# Altium schematic sheet 01_Power.SchDoc(01_Power)
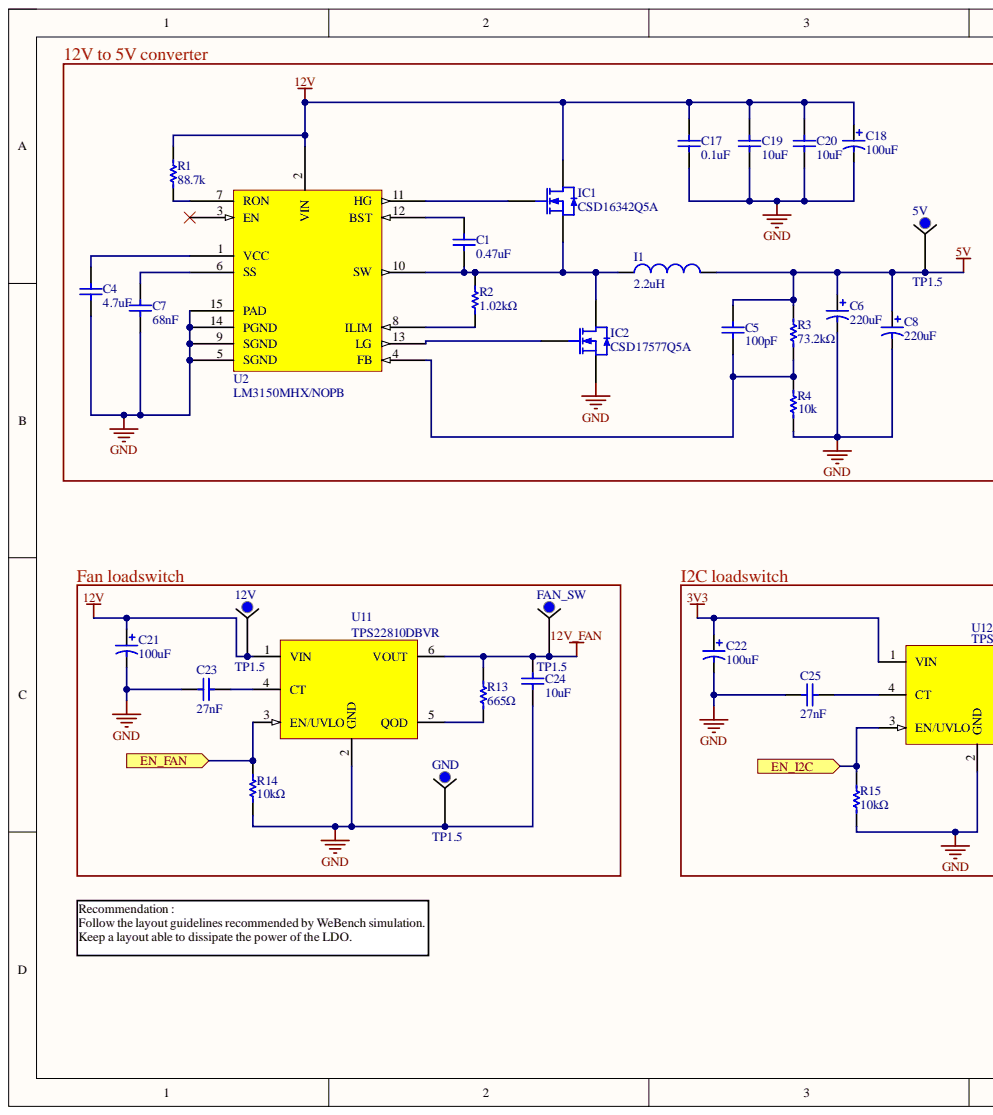
[diagram p0: 01_Power.SchDoc, left]
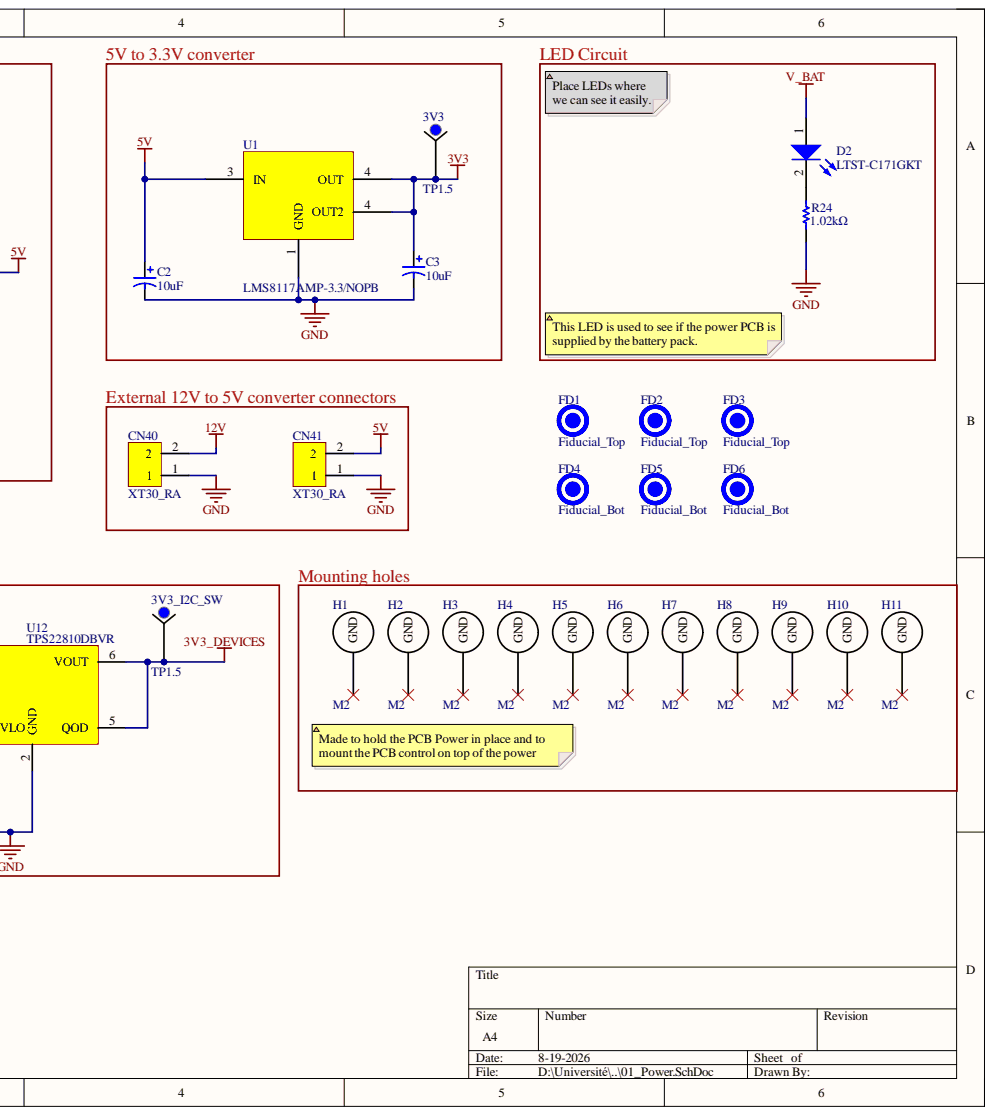
[diagram p1: 01_Power.SchDoc, right]

## Parts
3V3  TP1.5  [TP1.5]  (no BOM)
3V3_I2C_SW  TP1.5  [TP1.5]  (no BOM)
5V  TP1.5  [TP1.5]  (no BOM)
12V  TP1.5  [TP1.5]  (no BOM)
C1  0.47uF  [CAPC200X125X145L50N]  (no BOM)
C2  10uF  [CAPPM320X160X180L80X120N]  (no BOM)
C3  10uF  [CAPPM320X160X180L80X120N]  (no BOM)
C4  4.7uF  [CAPC200X125X145L50N]  (no BOM)
C5  100pF  [CAPC200X125X95L50N]  (no BOM)
C6  220uF  [CAPAE530X600N]  (no BOM)
C7  68nF  [CAPC200X125X95L50N]  (no BOM)
C8  220uF  [CAPAE530X600N]  (no BOM)
C17  0.1uF  [CAPC200X125X95L50N]  (no BOM)
C18  100uF  [CAPAE660X615D730L260X70N]  (no BOM)
C19  10uF  [CAPC320X250X270L60N]  (no BOM)
C20  10uF  [CAPC320X250X270L60N]  (no BOM)
C21  100uF  [CAPAE660X615D730L260X70N]
C22  100uF  [CAPAE660X615D730L260X70N]
C23  27nF  [CAPC200X125X145L50N]  (no BOM)
C24  10uF  [CAPC200X125X145L50N]
C25  27nF  [CAPC200X125X145L50N]  (no BOM)
CN40  XT30_RA  [XT30-90DEG]  (no BOM)
CN41  XT30_RA  [XT30-90DEG]  (no BOM)
D2  LTST-C171GKT  [LEDC200X125X80L45N]
FAN_SW  TP1.5  [TP1.5]  (no BOM)
FD1  Fiducial_Top  [Fiducial_Top]  (no BOM)
FD2  Fiducial_Top  [Fiducial_Top]  (no BOM)
FD3  Fiducial_Top  [Fiducial_Top]  (no BOM)
FD4  Fiducial_Bot  [Fiducial_Bot]  (no BOM)
FD5  Fiducial_Bot  [Fiducial_Bot]  (no BOM)
FD6  Fiducial_Bot  [Fiducial_Bot]  (no BOM)
GND  TP1.5  [TP1.5]  (no BOM)
H1  M2  [M2]  (no BOM)
H2  M2  [M2]  (no BOM)
H3  M2  [M2]  (no BOM)
H4  M2  [M2]  (no BOM)
H5  M2  [M2]  (no BOM)
H6  M2  [M2]  (no BOM)
H7  M2  [M2]  (no BOM)
H8  M2  [M2]  (no BOM)
H9  M2  [M2]  (no BOM)
H10  M2  [M2]  (no BOM)
H11  M2  [M2]  (no BOM)
I1  2.2uH  [INDDFN2_730X670X530L160X290]  (no BOM)
IC1  CSD16342Q5A  [MOSFET_CSD16342Q5A]  (no BOM)
IC2  CSD17577Q5A  [MOSFET_CSD17577Q5A]  (no BOM)
R1  88.7k  [RESC200X125X60L35N]  (no BOM)
R2  1.02kΩ  [RESC200X125X60L35N]  (no BOM)
R3  73.2kΩ  [RESC200X125X60L35N]  (no BOM)
R4  10k  [RESC200X125X60L35N]  (no BOM)
R13  665Ω  [RESC310X160X65L55N]  (no BOM)
R14  10kΩ  [RESC200X125X60L35N]
R15  10kΩ  [RESC200X125X60L35N]
R24  1.02kΩ  [RESC200X125X60L35N]
U1  LMS8117AMP-3.3/NOPB  [SOT223-4P230_700X180L75X75N]  (no BOM)
U2  LM3150MHX/NOPB  [SOP15P65_500X640X110L60X24T310X0N]  (no BOM)
U11  TPS22810DBVR  [SOT95P280X145-6N]
U12  TPS22810DBVR  [SOT95P280X145-6N]

## Ports
EN_FAN, EN_I2C

## Nets
3V3: 3V3.1 C3.1 C22.1 U1.4 U12.1(VIN)
3V3_DEVICES: 3V3_I2C_SW.1 U12.5(QOD) U12.6(VOUT)
5V: 5V.1 C2.1 C5.2 C6.1 C8.1 CN41.2 I1.2 R3.2 U1.3
12V: 12V.1 C17.2 C18.1 C19.2 C20.2 C21.1 CN40.2 IC1.3 R1.2 U2.2(VIN) U11.1(VIN)
12V_FAN: C24.2 FAN_SW.1 R13.2 U11.6(VOUT)
GND: C2.2 C3.2 C4.1 C6.2 C7.1 C8.2 C17.1 C18.2 C19.1 C20.1 C21.2 C22.2 C23.1 C24.1 C25.1 CN40.1 CN41.1 GND.1 IC2.1 R4.1 R14.1 R15.1 R24.1 U1.1 U2.5(SGND) U2.9(SGND) U2.14(PGND) U2.15(PAD) U11.2(GND) U12.2(GND)
V_BAT: D2.1
EN_FAN: R14.2 U11.3(EN/UVLO) ; port EN_FAN
EN_I2C: R15.2 U12.3(EN/UVLO) ; port EN_I2C
NetC1_1: C1.1 I1.1 IC1.1 IC2.3 R2.2 U2.10(SW)
NetC1_2: C1.2 U2.12(BST)
NetC4_2: C4.2 U2.1(VCC)
NetC5_1: C5.1 R3.1 R4.2 U2.4(FB)
NetC7_2: C7.2 U2.6(SS)
NetC23_2: C23.2 U11.4(CT)
NetC25_2: C25.2 U12.4(CT)
NetD2_2: D2.2 R24.2
NetIC1_2: IC1.2 U2.11(HG)
NetIC2_2: IC2.2 U2.13(LG)
NetR1_1: R1.1 U2.7(RON)
NetR2_1: R2.1 U2.8(ILIM)
NetR13_1: R13.1 U11.5(QOD)

Not connected: H1 1; H2 1; H3 1; H4 1; H5 1; H6 1; H7 1; H8 1; H9 1; H10 1; H11 1; U2 3(EN)

## Library data of the parts
3V3 3V3_I2C_SW 5V 12V FAN_SW GND = TP1.5: Testpoint 1.5mm; Library Name: PCB_Power.SchLib
C1 = CC0805KKX7R7BB474: CAP CER 0.47UF 16V X7R 0805; Manufacturer: Yageo; Detailed description: ±10% 16V Ceramic Capacitor X7R 0805 (2012 Metric); Operating description: -55°C ~ 125°C; ComponentLink1Description: Datasheet; ComponentLink1URL: https://www.yageo.com/upload/media/product/productsearch/datasheet/mlcc/UPY-GPHC_X7R_6.3V-to-50V_18.pdf; Library Name: PCB_Power.SchLib; Manufacturer part number: CC0805KKX7R7BB474; Price: 0.30; Supplier: Digikey; Supplier part number: 311-3384-1-ND; Value: 0.47uF
C2 C3 = 293D106X9016A2TE3: CAP TANT 10UF 10% 16V 1206; Manufacturer: Vishay Sprague; Detailed description: Molded Tantalum Capacitors 1206 (3216 Metric) 3Ohm; ComponentLink1Description: Datasheet; ComponentLink1URL: https://www.vishay.com/docs/40002/293d.pdf; Library Name: PCB_Power.SchLib; Manufacturer part number: 293D106X9016A2TE3; Operating Temperature: -55°C ~ 125°C; Price: 0.71; Supplier: Digikey; Supplier part number: 718-1123-1-ND; Value: 10uF
C4 = CC0805KKX7R7BB475: CAP CER 4.7UF 16V X7R 0805; Manufacturer: Yageo; Detailed description: ±10% 16V Ceramic Capacitor X7R 0805 (2012 Metric); ComponentLink1Description: Datasheet; ComponentLink1URL: https://www.yageo.com/upload/media/product/productsearch/datasheet/mlcc/UPY-GPHC_X7R_6.3V-to-50V_18.pdf; Library Name: PCB_Power.SchLib; Manufacturer part number: CC0805KKX7R7BB475; Operating temperature: -55°C ~ 125°C; Price: 0.62; Supplier: Digikey; Supplier part number: 311-1883-1-ND; Value: 4.7uF
C5 = CC0805JRNPO0BN101: CAP CER 100PF 100V C0G/NPO 0805; Manufacturer: Yageo; Detailed description: ±5% 100V Ceramic Capacitor C0G, NP0 0805 (2012 Metric); ComponentLink1Description: Datasheet; ComponentLink1URL: https://www.yageo.com/upload/media/product/products/datasheet/mlcc/UPY-NP0X7R_MV_100-to-630V_21.pdf; Library Name: PCB_Power.SchLib; Manufacturer part number: CC0805JRNPO0BN101; Operating temperature: -55°C ~ 125°C; Price: 0.19; Supplier: Digikey; Supplier part number: 311-1485-1-ND; Value: 100pF
C6 C8 = PEC_6SVPE220MW: CAP ALUM POLY 220UF 20% 6.3V SMD; Manufacturer: Panasonic Electronic Components; Detailed description: 220µF 6.3V Aluminum - Polymer Capacitors Radial, Can - SMD 15mOhm 2000 Hrs @ 105°C; ComponentLink1Description: Datasheet; ComponentLink1URL: https://industrial.panasonic.com/cdbs/www-data/pdf/AAB8000/AAB8000C179.pdf; LCSC_Part_Number: C186710; Library Name: PCB_Power.SchLib; Manufacturer part number: 6SVPE220MW; Operating temperature: -55°C ~ 105°C; Price: 0.98; Supplier: Digikey; Supplier part number: P16836CT-ND; Value: 220uF
C7 = CC0805KRX7R9BB683: CAP CER 0.068UF 50V X7R 0805; Manufacturer: Yageo; Detailed description: ±10% 50V Ceramic Capacitor X7R 0805 (2012 Metric); ComponentLink1Description: Datasheet; ComponentLink1URL: https://www.yageo.com/upload/media/product/productsearch/datasheet/mlcc/UPY-GPHC_X7R_6.3V-to-50V_18.pdf; Library Name: PCB_Power.SchLib; Manufacturer part number: CC0805KRX7R9BB683; Operating temperature: -55°C ~ 125°C; Price: 0.22; Supplier: Digikey; Supplier part number: 311-3394-1-ND; Value: 68nF
C17 = YAGEO_CC0805KRX7R7BB104: CAP CER 0.1UF 16V X7R 0805; Manufacturer: Yageo; Detailed description: ±10% 16V Ceramic Capacitor X7R 0805 (2012 Metric); ComponentLink1Description: Datasheet; ComponentLink1URL: https://www.yageo.com/upload/media/product/productsearch/datasheet/mlcc/UPY-GPHC_X7R_6.3V-to-50V_18.pdf; LCSC_Part_Number: C519980; Library Name: PCB_Power.SchLib; Manufacturer part number: CC0805KRX7R7BB104; Operating temperature: -55°C ~ 125°C; Price: 0.17; Supplier: Digikey; Supplier part number: 311-1142-1-ND; Value: 0.1uF
C18 C21 C22 = PEC_EEE-FK1E101XP: CAP ALUM 100UF 20% 25V SMD; Manufacturer: Panasonic Electronic Components; Detailed description: 100µF 25V Aluminum Electrolytic Capacitors Radial, Can - SMD  2000 Hrs @ 105°C; ComponentLink1Description: Datasheet; ComponentLink1URL: https://b2b-api.panasonic.eu/file_stream/pids/fileversion/3127; LCSC_Part_Number: C128510; Library Name: PCB_Power.SchLib; Manufacturer part number: EEE-FK1E101XP; Operating temperature: -55°C ~ 105°C; Price: 0.65; Supplier: Digikey; Supplier part number: PCE3797CT-ND; Value: 100uF
  C21 C22: Component Kind: Standard
C19 C20 = TY_TMK325BJ106MM-T: CAP CER 10UF 25V X5R 1210; Manufacturer: Taiyo Yuden; Detailed description: ±20% 25V Ceramic Capacitor X5R 1210 (3225 Metric); ComponentLink1Description: Datasheet; ComponentLink1URL: https://www.yuden.co.jp/productdata/catalog/mlcc06_e.pdf; LCSC_Part_Number: C92835; Library Name: PCB_Power.SchLib; Manufacturer part number: TMK325BJ106MM-T; Operating temperature: -55°C ~ 85°C; Price: 0.92; Supplier: Digikey; Supplier part number: 587-1374-1-ND; Value: 10uF
C23 C25 = TDK_C2012C0G1V273J060AC: CAP CER 0.027UF 35V NP0 0805; Manufacturer: TDK Corporation; Manufacturer part number: 445-174564-1-ND; Detailed description: ±5% 35V Ceramic Capacitor C0G, NP0 0805 (2012 Metric); ComponentLink1Description: Datasheet; ComponentLink1URL: https://product.tdk.com/info/en/catalog/datasheets/mlcc_commercial_general_en.pdf; LCSC_Part_Number: C3902600; Library Name: PCB_Power.SchLib; Operating temperature: -55°C ~ 125°C; Price: 0.43; Supplier: Digikey; Supplier part number: 445-174564-1-ND; Value: 27nF
C24 = YAGEO_CC0805MKX7R7BB106: CAP CER 10UF 16V X7R 0805; Manufacturer: Yageo; Detailed description: ±20% 16V Ceramic Capacitor X7R 0805 (2012 Metric); Component Kind: Standard; ComponentLink1Description: Datasheet; ComponentLink1URL: https://www.yageo.com/upload/media/product/productsearch/datasheet/mlcc/UPY-GPHC_X7R_6.3V-to-50V_18.pdf; LCSC_Part_Number: C1952587; Library Name: PCB_Power.SchLib; Manufacturer part number: CC0805MKX7R7BB106; Operating temperature: -55°C ~ 125°C; Price: 1.03; Supplier: Digikey; Supplier part number: 311-3508-1-ND; Value: 10uF
CN40 CN41 = HM_XT30_RA: Manufacturer: Home made; LCSC_Part_Number: Ne_pas_ajouter; Library Name: PCB_Power.SchLib; Supplier: Amazon
D2 = Lite_LTST-C171GKT: LED GREEN CLEAR CHIP SMD; Manufacturer: Lite-On Inc.; Detailed Description: Green 569nm LED Indication - Discrete 2.1V 0805 (2012 Metric); Unit Price/Quantity: 0.26; Color: Green; Component Kind: Standard; ComponentLink1Description: Datasheet; ComponentLink1URL: https://media.digikey.com/pdf/Data%20Sheets/Lite-On%20PDFs/LTST-C171GKT.pdf; LCSC_Part_Number: C913075; Library Name: PCB_Power.SchLib; Manufacturer Part Number: LTST-C171GKT; Operating Temperature: -55*C to +85*C; Supplier: Digikey; Supplier Part Number: 160-1423-1-ND
FD1-FD3 = Fiducial_Top: Fiducial - Round 1.5x3mm - Top Layer; Library Name: Protection_Lib.SchLib
FD4-FD6 = Fiducial_Bot: Fiducial - Round 1.5x3mm - Bottom Layer; Library Name: Protection_Lib.SchLib
I1 = MGV06052R2M-10: Shielded Molded Inductor 13.6mOhm Max Nonstandard; Manufacturer: Laird-Signal Integrity Products; ComponentLink1Description: Datasheet; ComponentLink1URL: https://media.digikey.com/pdf/Data%20Sheets/Steward%20PDFs/MGV0605.pdf; Detailed description: Shielded Molded Inductor 13.6mOhm Max Nonstandard; Library Name: PCB_Power.SchLib; Manufacturer part number: MGV06052R2M-10; Operating temperature: -40°C ~ 125°C; Price: 1.76; Supplier: Digikey; Supplier part number: 240-2911-1-ND; Value: 2.2uH
IC1 = TI_CSD16342Q5A: MOSFET N-CH 25V 100A 8SON; Manufacturer: Texas Instruments; Detailed description: N-Channel 25V 100A (Tc) 3W (Ta) Surface Mount 8-VSONP (5x6); ComponentLink1Description: Datasheet; ComponentLink1URL: https://www.ti.com/general/docs/suppproductinfo.tsp?distId=10&gotoUrl=http%3A%2F%2Fwww.ti.com%2Flit%2Fgpn%2Fcsd16342q5a; LCSC_Part_Number: C2760459; Library Name: PCB_Power.SchLib; Manufacturer part number: CSD16342Q5A; Operating temperature: -55°C ~ 150°C (TJ); Price: 1.50; Supplier: Digikey; Supplier part number: 296-30314-1-ND
IC2 = TI_CSD17577Q5A: MOSFET N-CH 30V 60A 8VSON; Manufacturer: Texas Instruments; Detailed description: N-Channel 30V 60A (Ta) 3W (Ta), 53W (Tc) Surface Mount 8-VSONP (5x6); ComponentLink1Description: Datasheet; ComponentLink1URL: https://www.ti.com/general/docs/suppproductinfo.tsp?distId=10&gotoUrl=http%3A%2F%2Fwww.ti.com%2Flit%2Fgpn%2Fcsd16342q5a; LCSC_Part_Number: C475504; Library Name: PCB_Power.SchLib; Manufacturer part number: CSD17577Q5A; Operating temperature: -55°C ~ 150°C (TJ); Price: 1.08; Supplier: Digikey; Supplier part number: 296-39996-1-ND
R1 = RC0805FR-0788K7L: RES SMD 88.7K OHM 1% 1/8W 0805; Manufacturer: Yageo; Detailed description: 88.7 ±1% 0.125W, 1/8W Chip Resistor 0805 (2012 Metric) - Thick Film; ComponentLink1Description: Datasheet; ComponentLink1URL: https://www.yageo.com/upload/media/product/productsearch/datasheet/rchip/PYu-RC_Group_51_RoHS_L_11.pdf; Library Name: PCB_Power.SchLib; Manufacturer part number: RC0805FR-0788K7L; Operating temperature: -55°C ~ 155°C; Price: 0.15; Supplier: Digikey; Supplier part number: 311-88.7KCRCT-ND; Value: 88.7k
R2 R24 = YAGEO_RC0805FR-071K02L: RES SMD 1.02K OHM 1% 1/8W 0805; Manufacturer: Yageo; Detailed description: 1.02 kOhms ±1% 0.125W, 1/8W Chip Resistor 0805 (2012 Metric)  Thick Film; Operating description: -55°C ~ 155°C; ComponentLink1Description: Datasheet; ComponentLink1URL: https://www.yageo.com/upload/media/product/productsearch/datasheet/rchip/PYu-RC_Group_51_RoHS_L_11.pdf; LCSC_Part_Number: C482939; Library Name: PCB_Power.SchLib; Manufacturer part number: RC0805FR-071K02L; Price: 0.15; Supplier: Digikey; Supplier part number: 311-1.02KCRCT-ND; Value: 1.02kΩ
  R24: Component Kind: Standard
R3 = RC0805FR-0773K2L: RES SMD 73.2K OHM 1% 1/8W 0805; Manufacturer: Yageo; Detailed description: 73.2 ±1% 0.125W, 1/8W Chip Resistor 0805 (2012 Metric) - Thick Film; ComponentLink1Description: Datasheet; ComponentLink1URL: https://www.yageo.com/upload/media/product/productsearch/datasheet/rchip/PYu-RC_Group_51_RoHS_L_11.pdf; LCSC_Part_Number: C273873; Library Name: PCB_Power.SchLib; Manufacturer part number: RC0805FR-0773K2L; Operating temperature: -55°C ~ 155°C; Price: 0.15; Supplier: Digikey; Supplier part number: 311-73.2KCRCT-ND; Value: 73.2kΩ
R4 = RC0805JR-0710KL: RES SMD 10K OHM 5% 1/8W 0805; Manufacturer: Yageo; Detailed description: 10 ±5% 0.125W, 1/8W Chip Resistor 0805 (2012 Metric) - Thick Film; ComponentLink1Description: Datasheet; ComponentLink1URL: https://www.yageo.com/upload/media/product/productsearch/datasheet/rchip/PYu-RC_Group_51_RoHS_L_11.pdf; Library Name: PCB_Power.SchLib; Manufacturer part number: RC0805JR-0710KL; Operating temperature: -55°C ~ 155°C; Price: 0.15; Supplier: Digikey; Supplier part number: 311-10KARCT-ND; Value: 10k
R13 = YAGEO_RC1206FR-07665RL: RES SMD 665 OHM 1% 1/4W 1206; Manufacturer: Yageo; Detailed description: 665 ±1% 0.25W, 1/4W Chip Resistor 1206 (3216 Metric) - Thick Film; ComponentLink1Description: Datasheet; ComponentLink1URL: https://www.yageo.com/upload/media/product/productsearch/datasheet/rchip/PYu-RC_Group_51_RoHS_L_11.pdf; LCSC_Part_Number: C488937; Library Name: PCB_Power.SchLib; Manufacturer part number: RC1206FR-07665RL; Operating temperature: -55°C ~ 155°C; Price: 0.15; Supplier: Digikey; Supplier part number: 311-665FRCT-ND; Value: 665Ω
R14 R15 = YAGEO_RC0805JR-0710KL: RES SMD 10K OHM 5% 1/8W 0805; Manufacturer: Yageo; Detailed description: 10 ±5% 0.125W, 1/8W Chip Resistor 0805 (2012 Metric) - Thick Film; Component Kind: Standard; ComponentLink1Description: Datasheet; ComponentLink1URL: https://www.yageo.com/upload/media/product/productsearch/datasheet/rchip/PYu-RC_Group_51_RoHS_L_11.pdf; LCSC_Part_Number: C100047; Library Name: PCB_Power.SchLib; Manufacturer part number: RC0805JR-0710KL; Operating temperature: -55°C ~ 155°C; Price: 0.15; Supplier: Digikey; Supplier part number: 311-10KARCT-ND; Value: 10kΩ
U1 = TI_LMS8117: IC REG LINEAR 3.3V 1A SOT223; Manufacturer: Texas Instruments; Detailed description: Linear Voltage Regulator IC Output 1A SOT-223; ComponentLink1Description: Datasheet; ComponentLink1URL: https://www.ti.com/general/docs/suppproductinfo.tsp?distId=10&gotoUrl=http%3A%2F%2Fwww.ti.com%2Flit%2Fgpn%2Flms8117a; LCSC_Part_Number: C201952; Library Name: PCB_Power.SchLib; Manufacturer part number: LMS8117AMP-3.3/NOPB; Operating temperature: 0°C ~ 125°C; Price: 1.83; Supplier: Digikey; Supplier part number: LMS8117AMP-3.3/NOPBCT-ND
U2 = TI_LM3150: IC REG CTRLR BUCK 14TSSOP; Manufacturer: Texas instrument; Detailed description: Buck Regulator Positive Output Step-Down - DC-DC Controller IC 14-HTSSOP; ComponentLink1Description: Datasheet; ComponentLink1URL: https://www.ti.com/general/docs/suppproductinfo.tsp?distId=10&gotoUrl=http%3A%2F%2Fwww.ti.com%2Flit%2Fgpn%2Flm3150; LCSC_Part_Number: C48112; Library Name: PCB_Power.SchLib; Manufacturer part number: LM3150MHX/NOPB; Operating temperature: -40°C ~ 125°C (TJ); Price: 4.85; Supplier: Digikey; Supplier part number: 296-35276-1-ND
U11 U12 = TI_TPS22810DBVR: IC PWR SWITCH N-CHAN 1:1 SOT23-6; Manufacturer: Texas Instruments; Detailed description: Power Switch/Driver 1:1 N-Channel 2A SOT-23-6; Component Kind: Standard; ComponentLink1Description: Datasheet; ComponentLink1URL: https://www.ti.com/general/docs/suppproductinfo.tsp?distId=10&gotoUrl=http%3A%2F%2Fwww.ti.com%2Flit%2Fgpn%2Ftps22810; LCSC_Part_Number: C205990; Library Name: PCB_Power.SchLib; Manufacturer part number: TPS22810DBVR; Operating temperature: -40°C ~ 105°C (TA); Price: 0.95; Supplier: Digikey; Supplier part number: 296-45297-1-ND
H1-H11 = M2: Library Name: PCB_Power.SchLib
